# Altium schematic sheet 2228_Emetteur_Systeme.SchDoc
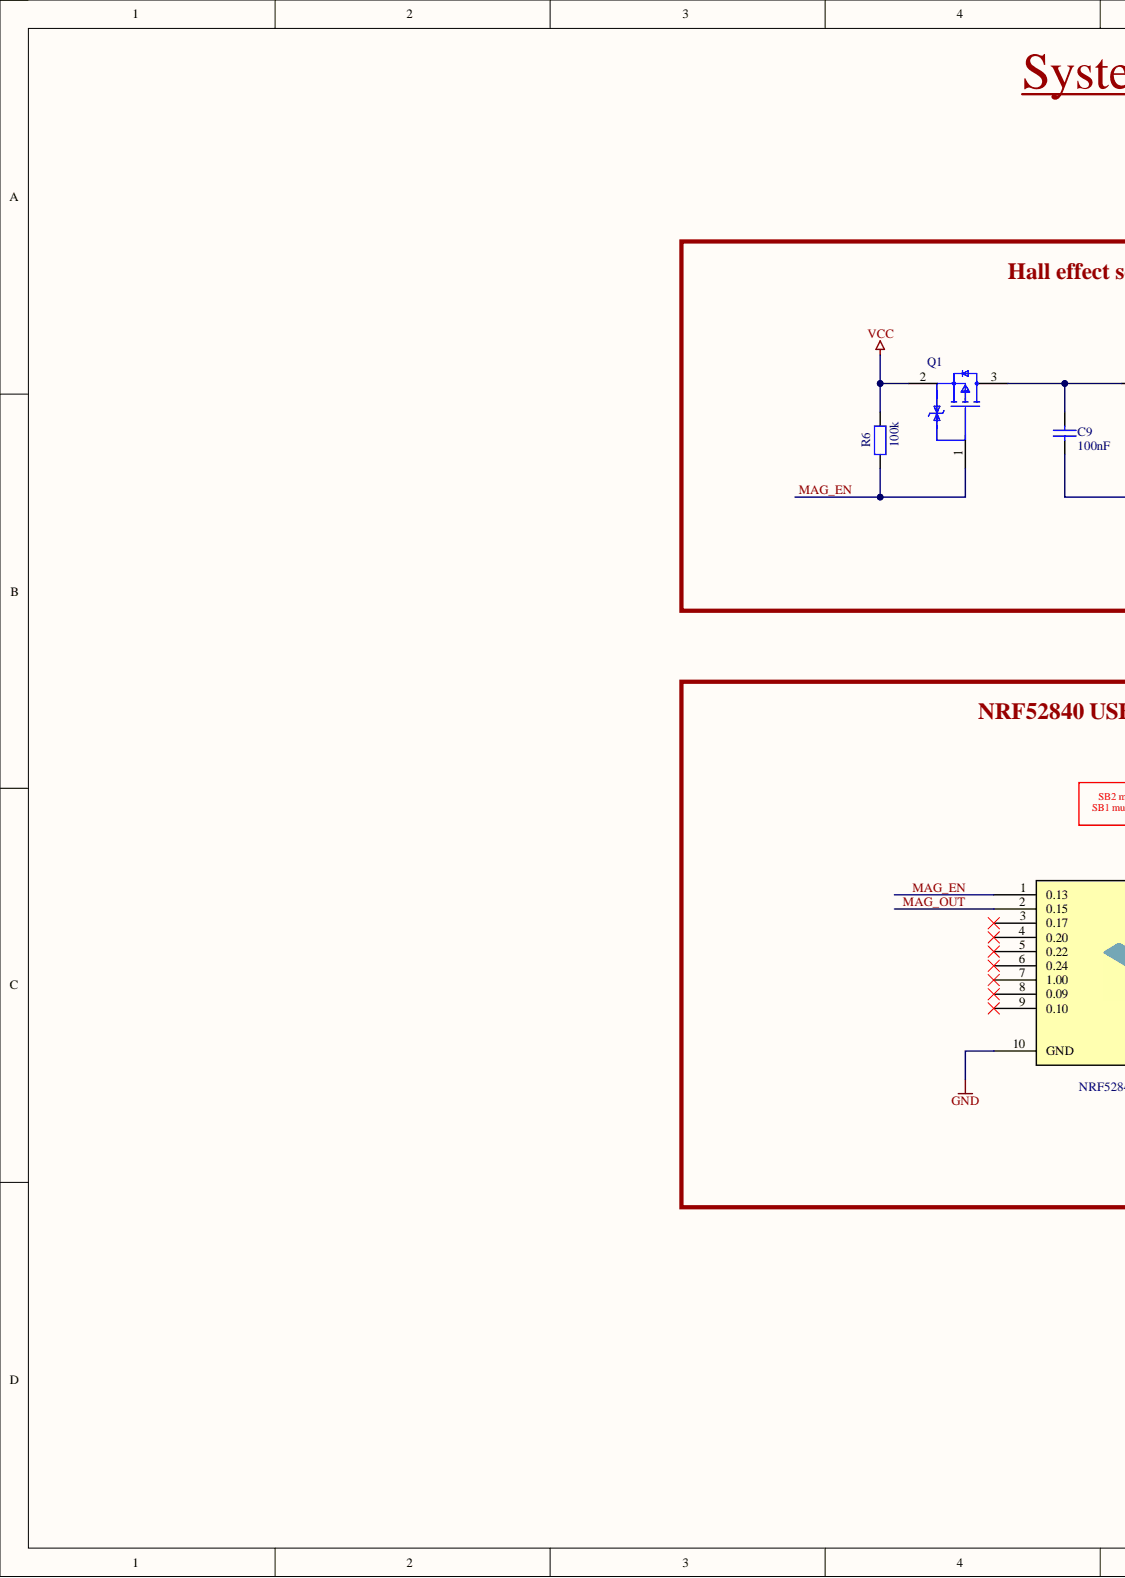
[diagram p0: 2228_Emetteur_Systeme.SchDoc, left]
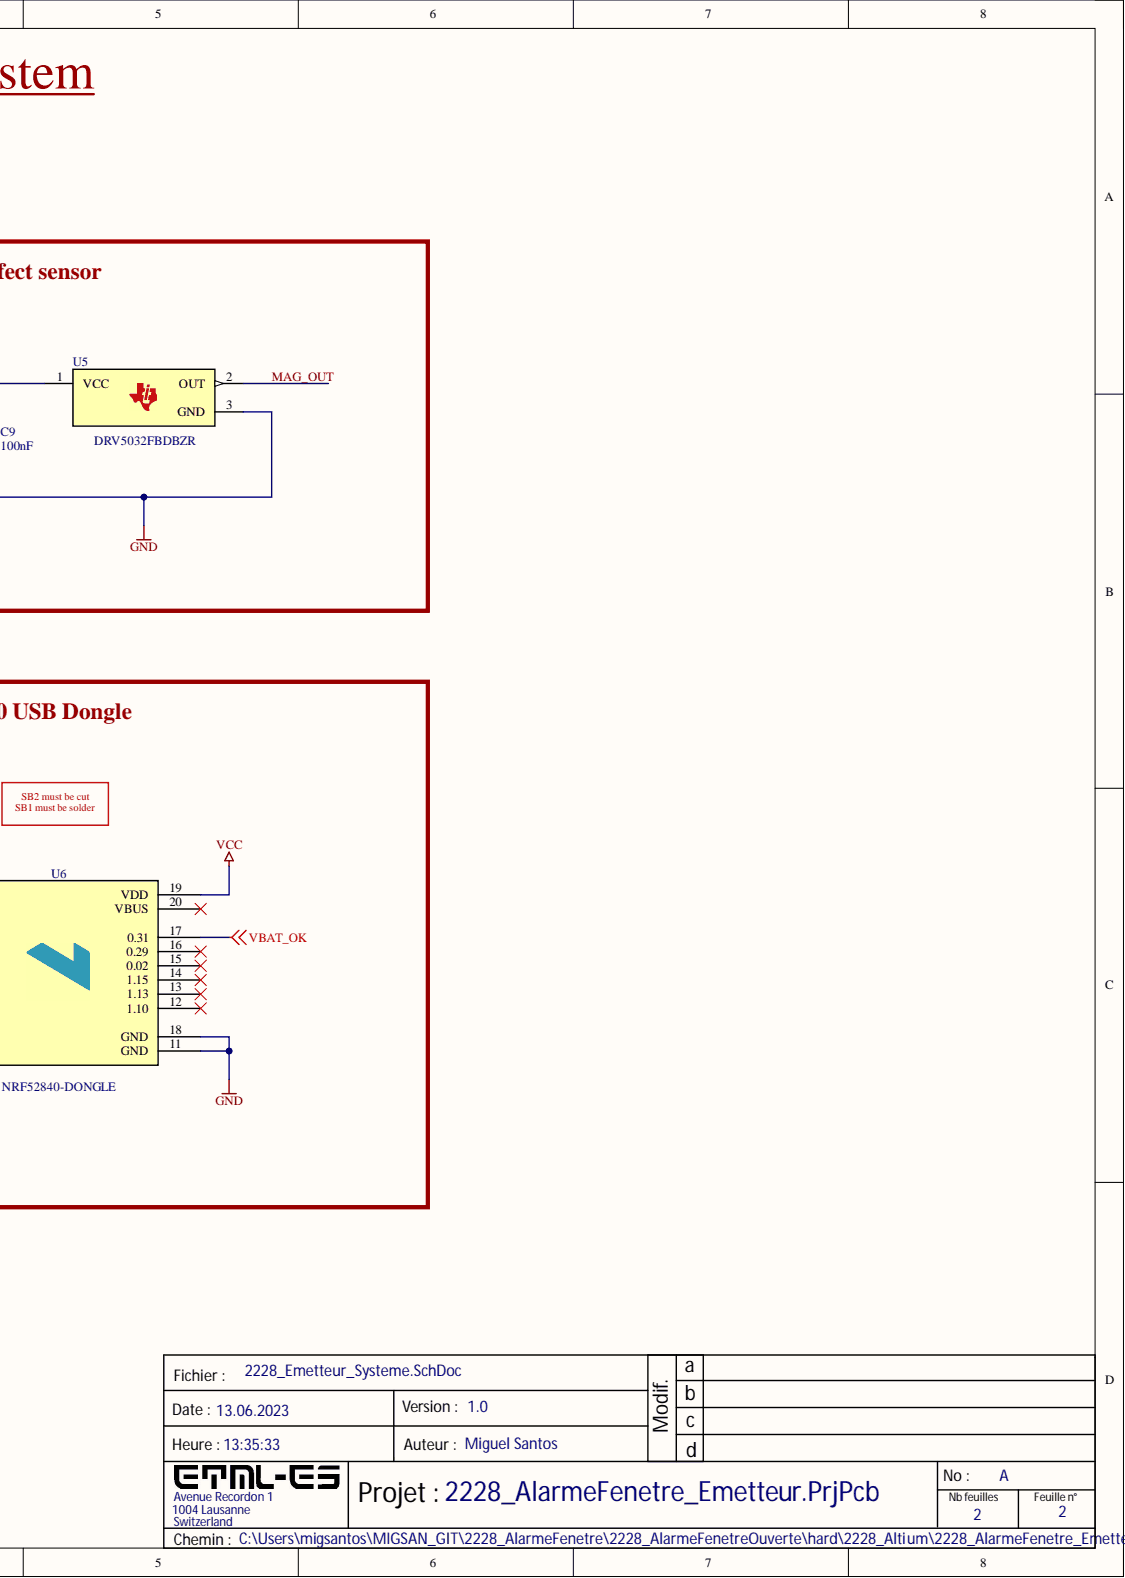
[diagram p1: 2228_Emetteur_Systeme.SchDoc, right]

## Parts
C9  value 100nF  [CAP_0805]  (hidden comment: CAP_SMD)
Q1  RZM001P02T2L  [FP-VMT3-MFG]  (value not drawn)
R6  value 100k  [RES_0805]  (hidden comment: RES_SMD)
U5  DRV5032FBDBZR  [SOT95P237X112-3N]
U6  NRF52840-DONGLE  [NRF52840_DONGLE]

## Nets
GND: C9.1 U5.3 U6.10(GND) U6.11(GND) U6.18(GND)
VBAT_OK: U6.17(0.31)
VCC: Q1.2 R6.2 U6.19(VDD)
MAG_EN: Q1.1 R6.1 U6.1(0.13)
MAG_OUT: U5.2 U6.2(0.15)
NetC9_2: C9.2 Q1.3 U5.1

Not connected: U6 3(0.17) 4(0.20) 5(0.22) 6(0.24) 7(1.00) 8(0.09) 9(0.10) 12(1.10) 13(1.13) 14(1.15) 15(0.02) 16(0.29) 20(VBUS)

## Library data of the parts
C9 = CAP_SMD: Capacitor; Component Kind: Standard; Library Name: 2228_AlarmeFenetre_Symbols.SchLib
Q1 = CMP-08982-000003-1: MOSFET P-CH 20V 0.1A VMT3; Manufacturer: Rohm; Continuous Drain Current: 100mA; Depletion Mode: False; Drain To Source Breakdown Voltage: -20V; Drain To Source Resistance: 2.5Ω; Gate To Source Voltage: 10V; Input Capacitace At Vds: 15pF @ -10V; Max Forward Diode Voltage: -1.2V; Max Junction Temp: +150°C; Number Of Channels: 1; Power Dissipation: 150W; Pulse Drain Current: 400mA; Rthja Max: 833°C/W; Standoff Height: 0mm; Threshold Vgs Max: -1V; Threshold Vgs Min: -0.3V; Turn Off Delay Time: 325ns; Turn On Delay Time: 46ns; Automotive: No; Category: Trans; Component Kind: Standard; ComponentLink2Description: Datasheet; ComponentLink2URL: https://www.rohm.com/datasheet/RZM001P02/rzm001p02t2l-e; Device Class L1: Discrete Semiconductors; Device Class L2: Transistors; Device Class L3: MOSFETs; Digikey Description: MOSFET P-CH 20V 0.1A VMT3; Drain To Source Voltage: -20V; Height: 0.55mm; Lead Free: Yes; Library Name: Altium Content Vault; Manufacturer Part Number: RZM001P02T2L; Mouser Description: MOSFET 1.2V Drive Pch MOSFET; Number Of P Channels: 1; Octopart: https://octopart.com/rzm001p02t2l-rohm-29677415; Package: VMT3; RoHS: TRUE; Temperature: -55°C to +150°C; Temperature Range High: +150°C; Temperature Range Low: -55°C
U5 = DRV5032FBDBZR: Manufacturer: Texas Instruments; Component Kind: Standard; Manufacturer_Number: DRV5032FBDBZR; Supplier 1: Digi-Key; Supplier Part Number 1: 296-47323-1-ND; Library Name: 2228_AlarmeFenetre_Symbols.SchLib
U6 = NRF52840-DONGLE: Manufacturer: Nordic Semiconductor; Component Kind: Standard; Manufacturer_Number: NRF52840-DONGLE; Library Name: 2228_AlarmeFenetre_Symbols.SchLib
R6 = RES_SMD: Component Kind: Standard; Library Name: 2228_AlarmeFenetre_Symbols.SchLib
